# Altium schematic sheet Xberry 3 FPGA.SchDoc("Xberry 3 FPGA")
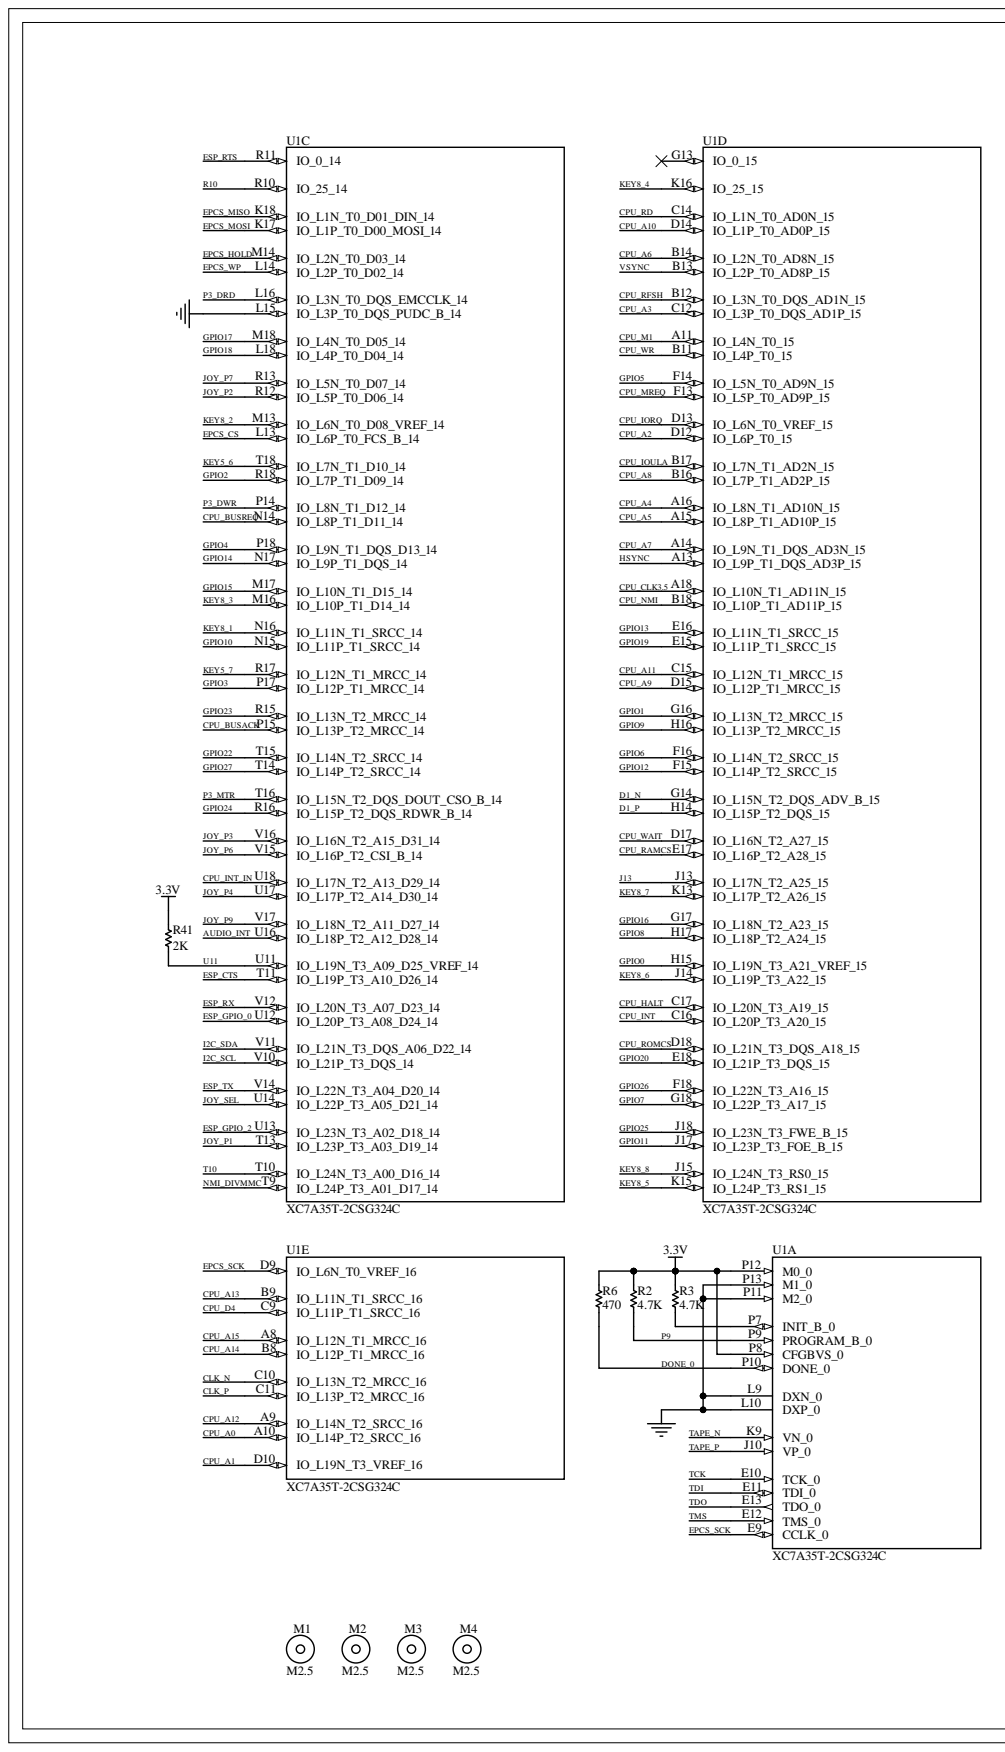
[diagram p0: Xberry 3 FPGA.SchDoc, left]
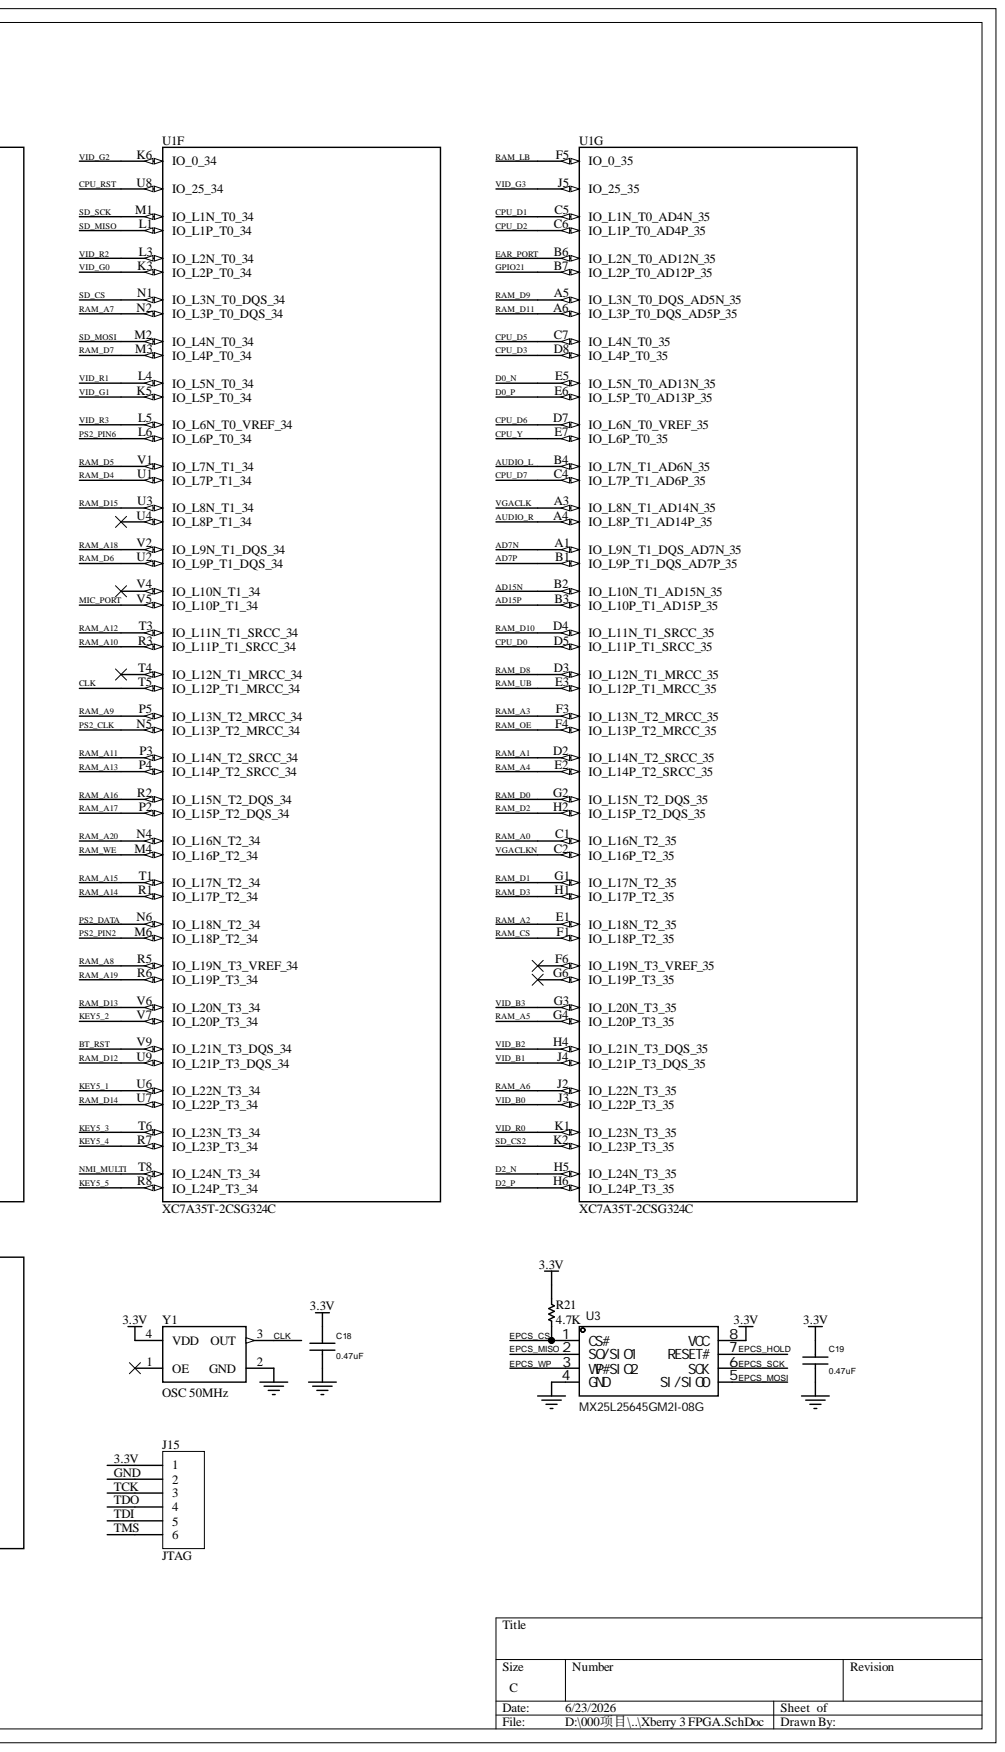
[diagram p1: Xberry 3 FPGA.SchDoc, right]

## Parts
C18  0.47uF  [CAP: 0402]
C19  0.47uF  [CAP: 0402]
J15  JTAG  [HDR1X6]
M1  M2.5  [M2.5]
M2  M2.5  [M2.5]
M3  M2.5  [M2.5]
M4  M2.5  [M2.5]
R2  4.7K  [RES: 0603]
R3  4.7K  [RES: 0603]
R6  470  [RES: 0603]
R21  4.7K  [RES: 0603]
R41  2K  [RES: 0603]
U1  XC7A35T-2CSG324C  [CSG324_XIL]  (parts A,C,D,E,F,G)
U3  MX25L25645GM2I-08G  [SOIC-8_L5.3-W5.3-P1.27-LS8.0-BL]
Y1  OSC 50MHz  [SM/OSC_ASFL1]

## Nets
3.3V: C18.1 C19.1 J15.1 R2.2 R3.2 R6.2 R21.2 R41.2 U1.P8(CFGBVS_0) U1.P12(M0_0) U3.8(VCC) Y1.4(VDD)
AD7N: U1.A1(IO_L9N_T1_DQS_AD7N_35)
AD7P: U1.B1(IO_L9P_T1_DQS_AD7P_35)
AD15N: U1.B2(IO_L10N_T1_AD15N_35)
AD15P: U1.B3(IO_L10P_T1_AD15P_35)
AUDIO_INT: U1.U16(IO_L18P_T2_A12_D28_14)
AUDIO_L: U1.B4(IO_L7N_T1_AD6N_35)
AUDIO_R: U1.A4(IO_L8P_T1_AD14P_35)
BT_RST: U1.V9(IO_L21N_T3_DQS_34)
CLK: U1.T5(IO_L12P_T1_MRCC_34) Y1.3(OUT)
CLK_N: U1.C10(IO_L13N_T2_MRCC_16)
CLK_P: U1.C11(IO_L13P_T2_MRCC_16)
CPU_A0: U1.A10(IO_L14P_T2_SRCC_16)
CPU_A1: U1.D10(IO_L19N_T3_VREF_16)
CPU_A2: U1.D12(IO_L6P_T0_15)
CPU_A3: U1.C12(IO_L3P_T0_DQS_AD1P_15)
CPU_A4: U1.A16(IO_L8N_T1_AD10N_15)
CPU_A5: U1.A15(IO_L8P_T1_AD10P_15)
CPU_A6: U1.B14(IO_L2N_T0_AD8N_15)
CPU_A7: U1.A14(IO_L9N_T1_DQS_AD3N_15)
CPU_A8: U1.B16(IO_L7P_T1_AD2P_15)
CPU_A9: U1.D15(IO_L12P_T1_MRCC_15)
CPU_A10: U1.D14(IO_L1P_T0_AD0P_15)
CPU_A11: U1.C15(IO_L12N_T1_MRCC_15)
CPU_A12: U1.A9(IO_L14N_T2_SRCC_16)
CPU_A13: U1.B9(IO_L11N_T1_SRCC_16)
CPU_A14: U1.B8(IO_L12P_T1_MRCC_16)
CPU_A15: U1.A8(IO_L12N_T1_MRCC_16)
CPU_BUSACK: U1.P15(IO_L13P_T2_MRCC_14)
CPU_BUSREQ: U1.N14(IO_L8P_T1_D11_14)
CPU_CLK3.5: U1.A18(IO_L10N_T1_AD11N_15)
CPU_D0: U1.D5(IO_L11P_T1_SRCC_35)
CPU_D1: U1.C5(IO_L1N_T0_AD4N_35)
CPU_D2: U1.C6(IO_L1P_T0_AD4P_35)
CPU_D3: U1.D8(IO_L4P_T0_35)
CPU_D4: U1.C9(IO_L11P_T1_SRCC_16)
CPU_D5: U1.C7(IO_L4N_T0_35)
CPU_D6: U1.D7(IO_L6N_T0_VREF_35)
CPU_D7: U1.C4(IO_L7P_T1_AD6P_35)
CPU_HALT: U1.C17(IO_L20N_T3_A19_15)
CPU_INT: U1.C16(IO_L20P_T3_A20_15)
CPU_INT_IN: U1.U18(IO_L17N_T2_A13_D29_14)
CPU_IORQ: U1.D13(IO_L6N_T0_VREF_15)
CPU_IOULA: U1.B17(IO_L7N_T1_AD2N_15)
CPU_M1: U1.A11(IO_L4N_T0_15)
CPU_MREQ: U1.F13(IO_L5P_T0_AD9P_15)
CPU_NMI: U1.B18(IO_L10P_T1_AD11P_15)
CPU_RAMCS: U1.E17(IO_L16P_T2_A28_15)
CPU_RD: U1.C14(IO_L1N_T0_AD0N_15)
CPU_RFSH: U1.B12(IO_L3N_T0_DQS_AD1N_15)
CPU_ROMCS: U1.D18(IO_L21N_T3_DQS_A18_15)
CPU_RST: U1.U8(IO_25_34)
CPU_WAIT: U1.D17(IO_L16N_T2_A27_15)
CPU_WR: U1.B11(IO_L4P_T0_15)
CPU_Y: U1.E7(IO_L6P_T0_35)
D0_N: U1.E5(IO_L5N_T0_AD13N_35)
D0_P: U1.E6(IO_L5P_T0_AD13P_35)
D1_N: U1.G14(IO_L15N_T2_DQS_ADV_B_15)
D1_P: U1.H14(IO_L15P_T2_DQS_15)
D2_N: U1.H5(IO_L24N_T3_35)
D2_P: U1.H6(IO_L24P_T3_35)
DONE_0: R6.1 U1.P10(DONE_0)
EAR_PORT: U1.B6(IO_L2N_T0_AD12N_35)
EPCS_CS: R21.1 U1.L13(IO_L6P_T0_FCS_B_14) U3.1(CS#)
EPCS_HOLD: U1.M14(IO_L2N_T0_D03_14) U3.7(RESET#)
EPCS_MISO: U1.K18(IO_L1N_T0_D01_DIN_14) U3.2(SO/SIO1)
EPCS_MOSI: U1.K17(IO_L1P_T0_D00_MOSI_14) U3.5(SI/SIO0)
EPCS_SCK: U1.D9(IO_L6N_T0_VREF_16) U1.E9(CCLK_0) U3.6(SCK)
EPCS_WP: U1.L14(IO_L2P_T0_D02_14) U3.3(WP#SIO2)
ESP_CTS: U1.T11(IO_L19P_T3_A10_D26_14)
ESP_GPIO_0: U1.U12(IO_L20P_T3_A08_D24_14)
ESP_GPIO_2: U1.U13(IO_L23N_T3_A02_D18_14)
ESP_RTS: U1.R11(IO_0_14)
ESP_RX: U1.V12(IO_L20N_T3_A07_D23_14)
ESP_TX: U1.V14(IO_L22N_T3_A04_D20_14)
GND: C18.2 C19.2 J15.2 U1.L9(DXN_0) U1.L10(DXP_0) U1.L15(IO_L3P_T0_DQS_PUDC_B_14) U1.P11(M2_0) U1.P13(M1_0) U3.4(GND) Y1.2(GND)
GPIO0: U1.H15(IO_L19N_T3_A21_VREF_15)
GPIO1: U1.G16(IO_L13N_T2_MRCC_15)
GPIO2: U1.R18(IO_L7P_T1_D09_14)
GPIO3: U1.P17(IO_L12P_T1_MRCC_14)
GPIO4: U1.P18(IO_L9N_T1_DQS_D13_14)
GPIO5: U1.F14(IO_L5N_T0_AD9N_15)
GPIO6: U1.F16(IO_L14N_T2_SRCC_15)
GPIO7: U1.G18(IO_L22P_T3_A17_15)
GPIO8: U1.H17(IO_L18P_T2_A24_15)
GPIO9: U1.H16(IO_L13P_T2_MRCC_15)
GPIO10: U1.N15(IO_L11P_T1_SRCC_14)
GPIO11: U1.J17(IO_L23P_T3_FOE_B_15)
GPIO12: U1.F15(IO_L14P_T2_SRCC_15)
GPIO13: U1.E16(IO_L11N_T1_SRCC_15)
GPIO14: U1.N17(IO_L9P_T1_DQS_14)
GPIO15: U1.M17(IO_L10N_T1_D15_14)
GPIO16: U1.G17(IO_L18N_T2_A23_15)
GPIO17: U1.M18(IO_L4N_T0_D05_14)
GPIO18: U1.L18(IO_L4P_T0_D04_14)
GPIO19: U1.E15(IO_L11P_T1_SRCC_15)
GPIO20: U1.E18(IO_L21P_T3_DQS_15)
GPIO21: U1.B7(IO_L2P_T0_AD12P_35)
GPIO22: U1.T15(IO_L14N_T2_SRCC_14)
GPIO23: U1.R15(IO_L13N_T2_MRCC_14)
GPIO24: U1.R16(IO_L15P_T2_DQS_RDWR_B_14)
GPIO25: U1.J18(IO_L23N_T3_FWE_B_15)
GPIO26: U1.F18(IO_L22N_T3_A16_15)
GPIO27: U1.T14(IO_L14P_T2_SRCC_14)
HSYNC: U1.A13(IO_L9P_T1_DQS_AD3P_15)
I2C_SCL: U1.V10(IO_L21P_T3_DQS_14)
I2C_SDA: U1.V11(IO_L21N_T3_DQS_A06_D22_14)
J13: U1.J13(IO_L17N_T2_A25_15)
JOY_P1: U1.T13(IO_L23P_T3_A03_D19_14)
JOY_P2: U1.R12(IO_L5P_T0_D06_14)
JOY_P3: U1.V16(IO_L16N_T2_A15_D31_14)
JOY_P4: U1.U17(IO_L17P_T2_A14_D30_14)
JOY_P6: U1.V15(IO_L16P_T2_CSI_B_14)
JOY_P7: U1.R13(IO_L5N_T0_D07_14)
JOY_P9: U1.V17(IO_L18N_T2_A11_D27_14)
JOY_SEL: U1.U14(IO_L22P_T3_A05_D21_14)
KEY5_1: U1.U6(IO_L22N_T3_34)
KEY5_2: U1.V7(IO_L20P_T3_34)
KEY5_3: U1.T6(IO_L23N_T3_34)
KEY5_4: U1.R7(IO_L23P_T3_34)
KEY5_5: U1.R8(IO_L24P_T3_34)
KEY5_6: U1.T18(IO_L7N_T1_D10_14)
KEY5_7: U1.R17(IO_L12N_T1_MRCC_14)
KEY8_1: U1.N16(IO_L11N_T1_SRCC_14)
KEY8_2: U1.M13(IO_L6N_T0_D08_VREF_14)
KEY8_3: U1.M16(IO_L10P_T1_D14_14)
KEY8_4: U1.K16(IO_25_15)
KEY8_5: U1.K15(IO_L24P_T3_RS1_15)
KEY8_6: U1.J14(IO_L19P_T3_A22_15)
KEY8_7: U1.K13(IO_L17P_T2_A26_15)
KEY8_8: U1.J15(IO_L24N_T3_RS0_15)
MIC_PORT: U1.V5(IO_L10P_T1_34)
NMI_DIVMMC: U1.T9(IO_L24P_T3_A01_D17_14)
NMI_MULTI: U1.T8(IO_L24N_T3_34)
P3_DRD: U1.L16(IO_L3N_T0_DQS_EMCCLK_14)
P3_DWR: U1.P14(IO_L8N_T1_D12_14)
P3_MTR: U1.T16(IO_L15N_T2_DQS_DOUT_CSO_B_14)
P9: R2.1 U1.P9(PROGRAM_B_0)
PS2_CLK: U1.N5(IO_L13P_T2_MRCC_34)
PS2_DATA: U1.N6(IO_L18N_T2_34)
PS2_PIN2: U1.M6(IO_L18P_T2_34)
PS2_PIN6: U1.L6(IO_L6P_T0_34)
R10: U1.R10(IO_25_14)
RAM_A0: U1.C1(IO_L16N_T2_35)
RAM_A1: U1.D2(IO_L14N_T2_SRCC_35)
RAM_A2: U1.E1(IO_L18N_T2_35)
RAM_A3: U1.F3(IO_L13N_T2_MRCC_35)
RAM_A4: U1.E2(IO_L14P_T2_SRCC_35)
RAM_A5: U1.G4(IO_L20P_T3_35)
RAM_A6: U1.J2(IO_L22N_T3_35)
RAM_A7: U1.N2(IO_L3P_T0_DQS_34)
RAM_A8: U1.R5(IO_L19N_T3_VREF_34)
RAM_A9: U1.P5(IO_L13N_T2_MRCC_34)
RAM_A10: U1.R3(IO_L11P_T1_SRCC_34)
RAM_A11: U1.P3(IO_L14N_T2_SRCC_34)
RAM_A12: U1.T3(IO_L11N_T1_SRCC_34)
RAM_A13: U1.P4(IO_L14P_T2_SRCC_34)
RAM_A14: U1.R1(IO_L17P_T2_34)
RAM_A15: U1.T1(IO_L17N_T2_34)
RAM_A16: U1.R2(IO_L15N_T2_DQS_34)
RAM_A17: U1.P2(IO_L15P_T2_DQS_34)
RAM_A18: U1.V2(IO_L9N_T1_DQS_34)
RAM_A19: U1.R6(IO_L19P_T3_34)
RAM_A20: U1.N4(IO_L16N_T2_34)
RAM_CS: U1.F1(IO_L18P_T2_35)
RAM_D0: U1.G2(IO_L15N_T2_DQS_35)
RAM_D1: U1.G1(IO_L17N_T2_35)
RAM_D2: U1.H2(IO_L15P_T2_DQS_35)
RAM_D3: U1.H1(IO_L17P_T2_35)
RAM_D4: U1.U1(IO_L7P_T1_34)
RAM_D5: U1.V1(IO_L7N_T1_34)
RAM_D6: U1.U2(IO_L9P_T1_DQS_34)
RAM_D7: U1.M3(IO_L4P_T0_34)
RAM_D8: U1.D3(IO_L12N_T1_MRCC_35)
RAM_D9: U1.A5(IO_L3N_T0_DQS_AD5N_35)
RAM_D10: U1.D4(IO_L11N_T1_SRCC_35)
RAM_D11: U1.A6(IO_L3P_T0_DQS_AD5P_35)
RAM_D12: U1.U9(IO_L21P_T3_DQS_34)
RAM_D13: U1.V6(IO_L20N_T3_34)
RAM_D14: U1.U7(IO_L22P_T3_34)
RAM_D15: U1.U3(IO_L8N_T1_34)
RAM_LB: U1.F5(IO_0_35)
RAM_OE: U1.F4(IO_L13P_T2_MRCC_35)
RAM_UB: U1.E3(IO_L12P_T1_MRCC_35)
RAM_WE: U1.M4(IO_L16P_T2_34)
SD_CS: U1.N1(IO_L3N_T0_DQS_34)
SD_CS2: U1.K2(IO_L23P_T3_35)
SD_MISO: U1.L1(IO_L1P_T0_34)
SD_MOSI: U1.M2(IO_L4N_T0_34)
SD_SCK: U1.M1(IO_L1N_T0_34)
T10: U1.T10(IO_L24N_T3_A00_D16_14)
TAPE_N: U1.K9(VN_0)
TAPE_P: U1.J10(VP_0)
TCK: J15.3 U1.E10(TCK_0)
TDI: J15.5 U1.E11(TDI_0)
TDO: J15.4 U1.E13(TDO_0)
TMS: J15.6 U1.E12(TMS_0)
U11: R41.1 U1.U11(IO_L19N_T3_A09_D25_VREF_14)
VGACLK: U1.A3(IO_L8N_T1_AD14N_35)
VGACLKN: U1.C2(IO_L16P_T2_35)
VID_B0: U1.J3(IO_L22P_T3_35)
VID_B1: U1.J4(IO_L21P_T3_DQS_35)
VID_B2: U1.H4(IO_L21N_T3_DQS_35)
VID_B3: U1.G3(IO_L20N_T3_35)
VID_G0: U1.K3(IO_L2P_T0_34)
VID_G1: U1.K5(IO_L5P_T0_34)
VID_G2: U1.K6(IO_0_34)
VID_G3: U1.J5(IO_25_35)
VID_R0: U1.K1(IO_L23N_T3_35)
VID_R1: U1.L4(IO_L5N_T0_34)
VID_R2: U1.L3(IO_L2N_T0_34)
VID_R3: U1.L5(IO_L6N_T0_VREF_34)
VSYNC: U1.B13(IO_L2P_T0_AD8P_15)
NetR3_1: R3.1 U1.P7(INIT_B_0)

Not connected: U1 F6(IO_L19N_T3_VREF_35) G6(IO_L19P_T3_35) G13(IO_0_15) T4(IO_L12N_T1_MRCC_34) U4(IO_L8P_T1_34) V4(IO_L10N_T1_34); Y1 1(OE)

## Library data of the parts
C18 C19 = CAP NP: COMPFIXED: NO; COMPGROUP: 0; COMPHEIGHT: 0.000; COMPLOC: [1255.000,1345.000]; COMPROT: 90; COMPSIDE: BOT; FPLIST: SM/C_0805,SM/C_0603; COMPKEY: NO; COMPLOCKED: NO; Component Kind: Standard; DECOUPLER: NO; MEMORY: NO
J15 = Header 6: Header, 6-Pin; Component Kind: Standard; LatestRevisionDate: 17-Jul-2002; LatestRevisionNote: Re-released for DXP Platform.; Library Name: Miscellaneous Connectors.IntLib; Signal Integrity: Connector; Publisher: Altium Limited
R2 R3 R6 R21 R41 = Res1: Resistor; Component Kind: Standard; LatestRevisionDate: 17-Jul-2002; LatestRevisionNote: Re-released for DXP Platform.; Library Name: Miscellaneous Devices.IntLib; PackageReference: AXIAL-0.3; Published: 8-Jun-2000; Signal Integrity: Res; Simulation: RESISTOR; Publisher: Altium Limited
  R2 R3 R21: Value: 4.7K
  R6: Value: 470
  R41: Value: 2K
U1 = XC7A15T-1CSG324C: Manufacturer_Part_Number: XC7A100T-1CSG324C; Mfr_Name: Xilinx Inc; Component Kind: Standard; Library Name: Artix-7 FPGA.SchLib; RefDes: RefDes; Type: DEV; Copyright: Copyright (C) 2024 Ultra Librarian. All rights reserved.
U3 = MX25L25645GM2I-08G: Manufacturer: MXIC(旺宏电子); Add into BOM: yes; Clock Frequency (fc): 133MHz; Data Retention - TDR (Year): 20 years; Interface Type: SPI; Memory Size: 256Mbit; Page Programming Time (Tpp): 250us; Supply Voltage: 2.7V~3.6V; Component Kind: Standard; Convert to PCB: yes; Datasheet: https://atta.szlcsc.com/upload/public/pdf/source/20211010/C2838827_4F25CEE92D647532F77D913FC2B8E956.pdf; Device: MX25L25645GM2I-08G; JLCPCB Part Class: Extended Part; LCSC Part Name: MX25L25645GM2I-08G; Manufacturer Part: MX25L25645GM2I-08G; Operating Temperature: -40℃~+85℃; Supplier: LCSC; Supplier Footprint: SOP-8-208mil; Supplier Part: C190799; Symbol: MX25L25645GM2I-08G; Library Name: Retro Computer.SchLib
Y1 = OSC: Component Kind: Standard; Library Name: Misc Devices 2.SchLib
M1-M4 = M2.5: Component Kind: Standard; Library Name: Misc Devices 2.SchLib
